# Altium schematic sheet TinyBeacon-144-RevD.SchDoc (continued)

## Library data of the parts
C1 C6 C7 C14 C16 C38 C41 C44 C47 C60 C62-C64 C66 C69-C71 = CMP-lc0pxm0az9mhmih9v1rd-1: Generic ceramic capacitor; Manufacturer Name: Murata; Manufacturer PN: GRM188R71H104KA93D; Dielectric: X7R; Lead-Free Status: Lead Free; Polarity: Non-Polarized; Rated Current: NC; Rated Voltage: 50V; Tolerance: ±10%; Category: Capacitor; Component Kind: Standard; Library Name: CommunityVault; Octopart Url: https://octopart.com/grm188r71h104ka93d-murata-196234; Package / Case: 0603 (1608 Metric); RoHS: Compliant; Supplier Name: Digikey; Supplier PN: 490-1519-1-ND; Supplier URL: http://www.digikey.ca/product-detail/en/GRM188R71H104KA93D/490-1519-1-ND/587854; Technology: Ceramic; VALUE: 100nF
C2 C10 = CMP-lc0pxm0az9mhmih9v1rd-1: Generic ceramic capacitor; Manufacturer Name: Murata; Manufacturer PN: GRM188R71E474KA12D; Dielectric: X7R; Lead-Free Status: Lead Free; Polarity: Non-Polarized; Rated Current: NC; Tolerance: ±10%; Category: Capacitor; Component Kind: Standard; Library Name: CommunityVault; Octopart Url: https://octopart.com/grm188r71e474ka12d-murata-196910; Package / Case: 0603 (1608 Metric); RoHS: Compliant; Supplier Name: Digikey; Supplier PN: 490-3291-1-ND; Supplier URL: http://www.digikey.ca/product-detail/en/GRM188R71E474KA12D/490-3291-1-ND/702832; Technology: Ceramic; VALUE: 470nF
C3 C11 = CMP-lc0pxm0az9mhmih9v1rd-1: Generic ceramic capacitor; Manufacturer Name: Murata; Manufacturer PN: GRM21BR71E475KA73L; Dielectric: X7R; Lead-Free Status: Lead Free; Polarity: Non-Polarized; Rated Current: NC; Tolerance: ±10%; Category: Capacitor; Component Kind: Standard; Library Name: CommunityVault; Octopart Url: https://octopart.com/grm21br71e475ka73l-murata-46693552; Package / Case: 0805 (2012 Metric); RoHS: Compliant; Supplier Name: Digikey; Supplier PN: 490-9962-1-ND; Supplier URL: http://www.digikey.ca/product-detail/en/GRM21BR71E475KA73L/490-9962-1-ND/5026449; Technology: Ceramic; VALUE: 4.7uF
C4 C15 = CMP-lc0pxm0az9mhmih9v1rd-1: Generic ceramic capacitor; Manufacturer Name: Murata; Manufacturer PN: GRM1885C1H471JA01D; Dielectric: C0G, NP0; Lead-Free Status: Lead Free; Polarity: Non-Polarized; Rated Current: NC; Tolerance: ±5%; Category: Capacitor; Component Kind: Standard; Library Name: CommunityVault; Octopart Url: https://octopart.com/grm1885c1h471ja01d-murata-196161; Package / Case: 0603 (1608 Metric); RoHS: Compliant; Supplier Name: Digikey; Supplier PN: 490-1443-1-ND; Supplier URL: http://www.digikey.ca/product-detail/en/GRM1885C1H471JA01D/490-1443-1-ND/587675; Technology: Ceramic; VALUE: 470pF
C5 C37 C39 = CMP-222772-3: Generic polarized capacitor; Manufacturer Name: AVX Corporation; Manufacturer PN: TPSA476K006R0800; Dielectric: Tantalum; Lead-Free Status: Lead Free; Rated Current: NC; Tolerance: ±10%; Category: Capacitor; Component Kind: Standard; Library Name: CommunityVault; Octopart Url: https://octopart.com/tpsa476k006r0800-avx-220336; Package / Case: 1206 (3216 Metric); Polarity: Polarized; RoHS: Compliant; Supplier Name: Digikey; Supplier PN: 478-3079-1-ND; Supplier URL: http://www.digikey.ca/product-detail/en/TPSA476K006R0800/478-3079-1-ND/809592; VALUE: 47uF
  C5 C37: Datasheet PDF: http://www.avx.com/docs/Catalogs/tps.pdf
C8 C17 C42 C45 C48 C72 = CMP-lc0pxm0az9mhmih9v1rd-1: Generic ceramic capacitor; Manufacturer Name: Murata; Manufacturer PN: GRM188R71H103KA01D; Dielectric: X7R; Lead-Free Status: Lead Free; Polarity: Non-Polarized; Rated Current: NC; Rated Voltage: 50V; Tolerance: ±10%; Category: Capacitor; Component Kind: Standard; Library Name: CommunityVault; Octopart Url: https://octopart.com/grm188r71h103ka01d-murata-81373; Package / Case: 0603 (1608 Metric); RoHS: Compliant; Supplier Name: Digikey; Supplier PN: 490-1512-1-ND; Supplier URL: http://www.digikey.ca/product-detail/en/GRM188R71H103KA01D/490-1512-1-ND/587862; Technology: Ceramic; VALUE: 10nF
C9 C18 C43 C46 C49-C51 C61 C65 C67 C73 = CMP-lc0pxm0az9mhmih9v1rd-1: Generic ceramic capacitor; Manufacturer Name: Murata; Manufacturer PN: GRM188R71H102KA01D; Dielectric: X7R; Lead-Free Status: Lead Free; Polarity: Non-Polarized; Rated Current: NC; Rated Voltage: 50V; Tolerance: ±10%; Category: Capacitor; Component Kind: Standard; Library Name: CommunityVault; Octopart Url: https://octopart.com/grm188r71h102ka01d-murata-62170; Package / Case: 0603 (1608 Metric); RoHS: Compliant; Supplier Name: Digikey; Supplier PN: 490-1494-1-ND; Supplier URL: http://www.digikey.ca/product-detail/en/GRM188R71H102KA01D/490-1494-1-ND/587851; Technology: Ceramic; VALUE: 1nF
C12 = CMP-222772-3: Generic polarized capacitor; Manufacturer Name: Kemet; Manufacturer PN: T494A106M020AT; Dielectric: Tantalum; Lead-Free Status: Lead Free; Rated Current: NC; Tolerance: ±20%; Category: Capacitor; Component Kind: Standard; Datasheet PDF: http://www.kemet.com/Lists/ProductCatalog/Attachments/256/KEM_T2008_T494.pdf; Library Name: CommunityVault; Octopart Url: https://octopart.com/t494a106m020at-kemet-11935444; Package / Case: 1206 (3216 Metric); Polarity: Polarized; RoHS: Compliant; Supplier Name: Digikey; Supplier PN: 399-5157-1-ND; Supplier URL: http://www.digikey.ca/product-detail/en/kemet/T494A106M020AT/399-5157-1-ND/1739493; VALUE: 10uF
C13 C74 = CMP-lc0pxm0az9mhmih9v1rd-2: Generic ceramic capacitor; Manufacturer Name: Murata; Manufacturer PN: GRM31CR61A476ME15L; Dielectric: X5R; Lead-Free Status: Lead Free; Polarity: Non-Polarized; Rated Current: NC; Rated Voltage: 10V; Tolerance: ±20%; Category: Capacitor; Component Kind: Standard; Library Name: CommunityVault; Octopart Url: https://octopart.com/grm31cr61a476me15l-murata-12963485; Package / Case: 1206 (3216 Metric); RoHS: Compliant; Supplier Name: Digikey; Supplier PN: 490-5528-1-ND; Supplier URL: http://www.digikey.ca/product-detail/en/GRM31CR61A476ME15L/490-5528-1-ND/2334924; Technology: Ceramic; VALUE: 47uF
C19 C57 = CMP-lc0pxm0az9mhmih9v1rd-1: Generic ceramic capacitor; Manufacturer Name: Murata; Manufacturer PN: GRM1885C1H470JA01D; Dielectric: C0G, NP0; Lead-Free Status: Lead Free; Polarity: Non-Polarized; Rated Current: NC; Rated Voltage: 50V; Tolerance: ±5%; Category: Capacitor; Component Kind: Standard; Library Name: CommunityVault; Octopart Url: https://octopart.com/grm1885c1h470ja01d-murata-196137; Package / Case: 0603 (1608 Metric); RoHS: Compliant; Supplier Name: Digikey; Supplier PN: 490-1419-1-ND; Supplier URL: http://www.digikey.ca/product-detail/en/murata-electronics-north-america/GRM1885C1H470JA01D/490-1419-1-ND/587616; Technology: Ceramic; VALUE: 47pF
C20 C23 = CMP-lc0pxm0az9mhmih9v1rd-1: Generic ceramic capacitor; Manufacturer Name: Murata; Manufacturer PN: GRM1885C1H152JA01D; Dielectric: C0G, NP0; Lead-Free Status: Lead Free; Polarity: Non-Polarized; Rated Current: NC; Rated Voltage: 50V; Tolerance: ±5%; Category: Capacitor; Component Kind: Standard; Library Name: CommunityVault; Octopart Url: https://octopart.com/grm1885c1h152ja01d-murata-196173; Package / Case: 0603 (1608 Metric); RoHS: Compliant; Supplier Name: Digikey; Supplier PN: 490-1455-1-ND; Supplier URL: http://www.digikey.ca/product-detail/en/GRM1885C1H152JA01D/490-1455-1-ND/587659; Technology: Ceramic; VALUE: 1500pF
C21 C22 C28 C30 = CMP-lc0pxm0az9mhmih9v1rd-1: Generic ceramic capacitor; Manufacturer Name: Murata; Manufacturer PN: GRM1885C1H101JA01D; Dielectric: C0G, NP0; Lead-Free Status: Lead Free; Polarity: Non-Polarized; Rated Current: NC; Rated Voltage: 50V; Tolerance: ±5%; Category: Capacitor; Component Kind: Standard; Library Name: CommunityVault; Octopart Url: https://octopart.com/grm1885c1h101ja01d-murata-196145; Package / Case: 0603 (1608 Metric); RoHS: Compliant; Supplier Name: Digikey; Supplier PN: 490-1427-1-ND; Supplier URL: http://www.digikey.ca/product-detail/en/murata-electronics-north-america/GRM1885C1H101JA01D/490-1427-1-ND/587633; Technology: Ceramic; VALUE: 100pF
C26 = CMP-lc0pxm0az9mhmih9v1rd-1: Generic ceramic capacitor; Manufacturer Name: Murata; Manufacturer PN: GRM188R71C105KA12D; Dielectric: X7R; Lead-Free Status: Lead Free; Polarity: Non-Polarized; Rated Current: NC; Rated Voltage: 16V; Tolerance: ±10%; Category: Capacitor; Component Kind: Standard; Library Name: CommunityVault; Octopart Url: https://octopart.com/grm188r71c105ka12d-murata-197452; Package / Case: 0603 (1608 Metric); RoHS: Compliant; Supplier Name: Digikey; Supplier PN: 490-3900-1-ND; Supplier URL: https://www.digikey.ca/product-detail/en/murata-electronics-north-america/GRM188R71C105KA12D/490-3900-1-ND/965942; Technology: Ceramic; VALUE: 1uF
C27 C29 C35 C53 C58 C59 = CMP-lc0pxm0az9mhmih9v1rd-1: Generic ceramic capacitor; Dielectric: NA; Lead-Free Status: Lead Free; Category: Capacitor; Component Kind: Standard; Library Name: CommunityVault; Manufacturer Name: NA; Manufacturer PN: NA; Octopart Url: NA; Package / Case: 0603 (1608 Metric); Polarity: NA; Rated Current: NA; Rated Voltage: NA; RoHS: Compliant; Supplier Name: NA; Supplier PN: NA; Supplier URL: NA; Technology: NA; Tolerance: NA; VALUE: NC
C34 = CMP-lc0pxm0az9mhmih9v1rd-1: Generic ceramic capacitor; Manufacturer Name: Murata; Manufacturer PN: GRM21BR60G107ME15L; Dielectric: X5R; Lead-Free Status: Lead Free; Polarity: Non-Polarized; Rated Voltage: 4V; Tolerance: ±20%; Category: Capacitor; Component Kind: Standard; Library Name: CommunityVault; Octopart Url: https://octopart.com/grm21br60g107me15l-murata-47096099; Package / Case: 0805 (2012 Metric); RoHS: Compliant; Supplier Name: Digikey; Supplier PN: 490-10510-1-ND; Supplier URL: https://www.digikey.ca/product-detail/en/murata-electronics-north-america/GRM21BR60G107ME15L/490-10510-1-ND/5026439; Technology: Ceramic; VALUE: 100uF
C40 = CMP-222772-3: Generic polarized capacitor; Manufacturer Name: AVX; Manufacturer PN: TLJA476M010R0600; Dielectric: Tantalum; Lead-Free Status: Lead Free; Rated Current: NC; Tolerance: ±20%; Category: Capacitor; Component Kind: Standard; Datasheet PDF: http://www.kemet.com/Lists/ProductCatalog/Attachments/254/KEM_T2005_T491.pdf; Library Name: CommunityVault; Octopart Url: https://octopart.com/tlja476m010r0600-avx-8398101; Package / Case: 1206 (3216 Metric); Polarity: Polarized; RoHS: Compliant; Supplier Name: Digikey; Supplier PN: 478-9009-1-ND; Supplier URL: http://www.digikey.ca/product-detail/en/avx-corporation/TLJA476M010R0600/478-9009-1-ND/4561875; VALUE: 47uF
C52 = CMP-lc0pxm0az9mhmih9v1rd-1: Generic ceramic capacitor; Manufacturer Name: Murata; Manufacturer PN: GRM1885C2A102JA01J; Dielectric: C0G, NP0; Lead-Free Status: Lead Free; Polarity: Non-Polarized; Rated Current: NC; Tolerance: ±5%; Category: Capacitor; Component Kind: Standard; Library Name: CommunityVault; Octopart Url: https://octopart.com/grm1885c2a102ja01j-murata-17287824; Package / Case: 0603 (1608 Metric); RoHS: Compliant; Supplier Name: Digikey; Supplier PN: 490-8275-1-ND; Supplier URL: http://www.digikey.ca/product-detail/en/murata-electronics-north-america/GRM1885C2A102JA01J/490-8275-1-ND/4380569; Technology: Ceramic; VALUE: 1nF
C54 = CMP-lc0pxm0az9mhmih9v1rd-1: Generic ceramic capacitor; Manufacturer Name: Murata; Manufacturer PN: GRM1885C2A620JA01D; Dielectric: C0G, NP0; Lead-Free Status: Lead Free; Polarity: Non-Polarized; Rated Current: NC; Tolerance: ±5%; Category: Capacitor; Component Kind: Standard; Library Name: CommunityVault; Octopart Url: https://octopart.com/grm1885c2a620ja01d-murata-196064; Package / Case: 0603 (1608 Metric); RoHS: Compliant; Supplier Name: Digikey; Supplier PN: 490-1346-1-ND; Supplier URL: http://www.digikey.ca/product-detail/en/murata-electronics-north-america/GRM1885C2A620JA01D/490-1346-1-ND/587687; Technology: Ceramic; VALUE: 62pF
C55 = CMP-lc0pxm0az9mhmih9v1rd-1: Generic ceramic capacitor; Manufacturer Name: Murata; Manufacturer PN: GRM1885C2A470JA01D; Dielectric: C0G, NP0; Lead-Free Status: Lead Free; Polarity: Non-Polarized; Rated Current: NC; Rated Voltage: 100V; Tolerance: ±5%; Category: Capacitor; Component Kind: Standard; Library Name: CommunityVault; Octopart Url: https://octopart.com/search?q=GRM1885C2A470JA01D; Package / Case: 0603 (1608 Metric); RoHS: Compliant; Supplier Name: Digikey; Supplier PN: 490-1343-1-ND; Supplier URL: http://www.digikey.ca/product-detail/en/murata-electronics-north-america/GRM1885C2A470JA01D/490-1343-1-ND/587692; Technology: Ceramic; VALUE: 47pF
C56 = CMP-lc0pxm0az9mhmih9v1rd-1: Generic ceramic capacitor; Manufacturer Name: Murata; Manufacturer PN: GRM1885C2A150FA01D; Dielectric: C0G, NP0; Lead-Free Status: Lead Free; Polarity: Non-Polarized; Rated Current: NC; Rated Voltage: 100V; Tolerance: ±1%; Category: Capacitor; Component Kind: Standard; Library Name: CommunityVault; Octopart Url: https://octopart.com/grm1885c2a150fa01d-murata-7895298; Package / Case: 0603 (1608 Metric); RoHS: Compliant; Supplier Name: Digikey; Supplier PN: 490-13359-1-ND; Supplier URL: http://www.digikey.ca/product-detail/en/murata-electronics-north-america/GRM1885C2A150FA01D/490-13359-1-ND/5973368; Technology: Ceramic; VALUE: 15pF
C75 C78 = CMP-lc0pxm0az9mhmih9v1rd-1: Generic ceramic capacitor; Manufacturer Name: Murata; Manufacturer PN: GRM1885C2A390JA01D; Dielectric: C0G, NP0; Lead-Free Status: Lead Free; Polarity: Non-Polarized; Rated Current: NC; Tolerance: ±5%; Category: Capacitor; Component Kind: Standard; Library Name: CommunityVault; Octopart Url: https://octopart.com/grm1885c2a390ja01d-murata-196059; Package / Case: 0603 (1608 Metric); RoHS: Compliant; Supplier Name: Digikey; Supplier PN: 490-1341-1-ND; Supplier URL: http://www.digikey.ca/product-detail/en/murata-electronics-north-america/GRM1885C2A390JA01D/490-1341-1-ND/587699; Technology: Ceramic; VALUE: 39pF
C76 C77 = CMP-lc0pxm0az9mhmih9v1rd-1: Generic ceramic capacitor; Manufacturer Name: Murata; Manufacturer PN: GRM1885C2A270JA01D; Dielectric: C0G, NP0; Lead-Free Status: Lead Free; Polarity: Non-Polarized; Rated Current: NC; Tolerance: ±5%; Category: Capacitor; Component Kind: Standard; Library Name: CommunityVault; Octopart Url: https://octopart.com/grm1885c2a270ja01d-murata-196055; Package / Case: 0603 (1608 Metric); RoHS: Compliant; Supplier Name: Digikey; Supplier PN: 490-1337-1-ND; Supplier URL: http://www.digikey.ca/product-detail/en/murata-electronics-north-america/GRM1885C2A270JA01D/490-1337-1-ND/587712; Technology: Ceramic; VALUE: 27pF
CV1 CV2 = CMP-9khhv8lmuc68m8vugv60-1: Generic variable capacitor; Manufacturer Name: Murata; Manufacturer PN: TZC3P200A110B00; Lead-Free Status: Lead Free; Polarity: Non-Polarized; Rated Current: NC; Serie: TZC3; Tolerance: NA; Category: Variable capacitor; Component Kind: Standard; Library Name: CommunityVault; Octopart Url: https://octopart.com/tzc3p200a110b00-murata-889206; Package / Case: Rectangular 4.50mm x 3.20mm; RoHS: Compliant; Supplier Name: Digikey; Supplier PN: 490-7154-ND; Supplier URL: http://www.digikey.ca/product-detail/en/murata-electronics-north-america/TZC3P200A110B00/490-7154-ND/2605008; VALUE: 5~20pF
D1-D3 = CMP-saaxis6nhgugjk9pybdm-4: Schottky Diode; Manufacturer Name: Diodes Incorporated; Manufacturer PN: B220A-13-F; Lead-Free Status: Lead Free; Rated Current: 2A; Rated Voltage: 20V; Voltage - Forward (Vf): 500mV @ 2A; Category: Schottky Diode; Component Kind: Standard; CustomComponentID: saaxis6nhgugjk9pybdm; Datasheet PDF: http://www.diodes.com/_files/datasheets/ds13004.pdf; Library Name: CommunityVault; Octopart Url: https://octopart.com/b220a-13-f-diodes+inc.-325773; Package / Case: SMA (DO-214AC); RoHS: Compliant; Supplier Name: Digikey; Supplier PN: B220A-FDICT-ND; Supplier URL: http://www.digikey.ca/product-detail/en/B220A-13-F/B220A-FDICT-ND/751528
D4 = CMP-284605-3: Generic TVS diode; Manufacturer Name: Murata; Lead-Free Status: Lead Free; Rated Voltage: 15V; Category: TVS Diode; Component Kind: Standard; Library Name: CommunityVault; Mounting Technology: LXES15AAA1-117; Octopart Url: https://octopart.com/lxes15aaa1-117-murata-30862315; Package / Case: 0402 (1005 Metric); RoHS: Compliant; Supplier Name: Digikey; Supplier PN: 490-9330-1-ND; Supplier URL: http://www.digikey.ca/product-detail/en/LXES15AAA1-117/490-9330-1-ND/4834048
D5 D8 = CMP-1544858-6: Green LED; Manufacturer Name: Lite-On; Manufacturer PN: LTST-C171KGKT; Brightness: 35mcd; Lead-Free Status: Lead Free; Rated Current: 20mA; Wavelength: 571nm; Category: LED; Color: Green; Component Kind: Standard; Datasheet PDF: http://optoelectronics.liteon.com/upload/download/DS22-2000-118/S_110_LTST-C171KGKT.pdf; Library Name: CommunityVault; Octopart URL: https://octopart.com/ltst-c171kgkt-lite-on-549888; Package / Case: 0805 (2012 Metric); RoHS: Compliant; Supplier Name: Digikey; Supplier PN: 160-1426-1-ND; Supplier URL: http://www.digikey.ca/product-detail/en/lite-on-inc/LTST-C171KGKT/160-1426-1-ND/386798
D6 = CMP-1544858-7: Red LED; Manufacturer Name: Lite-On; Manufacturer PN: LTST-C171KRKT; Brightness: 54 mcd; Lead-Free Status: Lead Free; Rated Current: 20mA; Wavelength: 631nm; Category: LED; Color: Red; Component Kind: Standard; Datasheet PDF: http://optoelectronics.liteon.com/upload/download/DS22-2000-109/S_110_LTST-C171KRKT.pdf; Library Name: CommunityVault; Octopart URL: https://octopart.com/ltst-c171krkt-lite-on-549889; Package / Case: 0805 (2012 Metric); RoHS: Compliant; Supplier Name: Digikey; Supplier PN: 160-1427-1-ND; Supplier URL: http://www.digikey.ca/product-detail/en/LTST-C171KRKT/160-1427-1-ND/386800
D7 = CMP-1544858-8: Yellow LED; Manufacturer Name: Lite-On; Manufacturer PN: LTST-C171KSKT; Brightness: 50mcd; Color: Red; Lead-Free Status: Lead Free; Rated Current: 20mA; Wavelength: 587nm; Category: LED; Component Kind: Standard; Datasheet PDF: http://optoelectronics.liteon.com/upload/download/DS22-2000-110/S_110_LTST-C171KSKT.pdf; Library Name: CommunityVault; Octopart URL: https://octopart.com/ltst-c171kskt-lite-on-549859; Package / Case: 0805 (2012 Metric); RoHS: Compliant; Supplier Name: Digikey; Supplier PN: 160-1428-1-ND; Supplier URL: http://www.digikey.ca/product-detail/en/lite-on-inc/LTST-C171KSKT/160-1428-1-ND/386802
F1-F5 = CMP-gkzqqvpzrlrunm2b6w61-3: Fixation Round 3mm; Lead-Free Status: Lead Free; Component Kind: Standard; CustomComponentID: gkzqqvpzrlrunm2b6w61; Library Name: CommunityVault; RoHS: Compliant
  F5: Category: PCB
FB1-FB6 = CMP-vk70ppgduhlrisxj3t6o-3: Generic ferrite bead; Manufacturer Name: Murata; Manufacturer PN: BLM21AG471SN1D; DC Resistance (DCR) (Max): 190 mOhm; Impedance @ Frequency: 470 Ohm @ 100MHz; Lead-Free Status: Lead Free; Rated Current: 700mA; Rated Voltage: NC; Category: Filter; Component Kind: Standard; Library Name: CommunityVault; Octopart Url: https://octopart.com/blm21ag471sn1d-murata-368342; Package / Case: 0805 (2012 Metric); RoHS: Compliant; Supplier Name: Digikey; Supplier PN: 490-1039-1-ND; Supplier URL: http://www.digikey.ca/product-detail/en/murata-electronics-north-america/BLM21AG471SN1D/490-1039-1-ND/584487; VALUE: 470R
J1 = CMP-4734335-6: Power Jack 2.1mm connector; Lead-Free Status: Lead Free; Category: Connector; Component Kind: Standard; Datasheet PDF: http://www.cui.com/product/resource/pj-002ah-smt.pdf; Library Name: CommunityVault; Manufacturer Name: CUI; Manufacturer PN: PJ-002AH-SMT-TR; OctopartID: 294ae1cbe021f68d; Octopart Url: https://octopart.com/pj-002ah-smt-tr-cui-25947149; Package / Case: Custom; RoHS: Compliant; Supplier Name: Digikey; Supplier PN: CP-002AHPJCT-ND; Supplier URL: http://www.digikey.ca/product-detail/en/PJ-002AH-SMT-TR/CP-002AHPJCT-ND/669692
J2 J3 = CMP-bd3b743192d962fc-7: SMA Jack; Manufacturer Name: Cinch Connectivity Solutions Johnson; Manufacturer PN: 142-0701-801; Lead-Free Status: Lead Free; Category: Connector; Component Kind: Standard; Datasheet PDF: https://cinchconnectivity.com/OA_MEDIA/drawings/dr-1420701801.pdf; Library Name: CommunityVault; OctopartID: bd3b743192d962fc; Octopart URL: http://octopart.com/142-0701-801-emerson+network+power+-+johnson-2692; Package / Case: SMA; RoHS: Compliant; Size-Height: 7.92 mm; Size-Length: 11.2 mm; Supplier Name: Digikey; Supplier PN: J502-ND; Supplier URL: http://www.digikey.com/product-detail/en/142-0701-801/J502-ND/35280
L1 = CMP-vwua5nnhs1xk3xc2ttx4-1: Inductor Shielded; Manufacturer Name: Murata Electronics; Manufacturer PN: LQH55DN6R8M03L; DC Resistance (DCR): 103.6 mOhm Max; Frequency - Self Resonant: 25MHz; Lead-Free Status: Lead Free; Q: NC; Tolerance: ±20%; Category: Inductor; Component Kind: Standard; Library Name: CommunityVault; Octopart Url: https://octopart.com/lqh55dn6r8m03l-murata-892319; Package / Case: 2220 (5650 Metric); Rated Voltage: NC; RoHS: Compliant; Supplier Name: Digikey; Supplier PN: 490-11697-1-ND; Supplier URL: http://www.digikey.ca/product-detail/en/LQH55DN6R8M03L/490-11697-1-ND/5333537; Technology: Ferrite; VALUE: 6.8uH
L2 = CMP-vwua5nnhs1xk3xc2ttx4-1: Inductor Shielded; Manufacturer Name: Murata Electronics; Manufacturer PN: LQH55DN4R7M03L; DC Resistance (DCR): 57.4 mOhm Max; Frequency - Self Resonant: 30 MHz; Lead-Free Status: Lead Free; Q: NC; Tolerance: ±20%; Category: Inductor; Component Kind: Standard; Library Name: CommunityVault; Octopart Url: https://octopart.com/lqh55dn4r7m03l-murata-890441; Package / Case: 2220 (5650 Metric); Rated Voltage: NC; RoHS: Compliant; Supplier Name: Digikey; Supplier PN: 490-9760-1-ND; Supplier URL: http://www.digikey.ca/product-detail/en/LQH55DN4R7M03L/490-9760-1-ND/4934959; Technology: Ferrite; VALUE: 4.7uH
L3 L8 = CMP-ikx6rag9xh6lf8sgm74d-2: Generic inductor; Manufacturer Name: Abracon LLC; Manufacturer PN: AISC-0805-R027G-T; DC Resistance (DCR): 170 mOhm Max; Lead-Free Status: Lead Free; Q: 50 @ 500MHz; Rated Current: 600mA; Rated Voltage: NC; Tolerance: ±2%; Category: Inductor; Component Kind: Standard; Datasheet PDF: http://www.abracon.com/Magnetics/new/AISC-0805(F).pdf; Library Name: CommunityVault; Octopart Url: https://octopart.com/aisc-0805-r027g-t-abracon-22130219; Package / Case: 0805; RoHS: Compliant; Supplier Name: Digikey; Supplier PN: AISC-0805-R027G-TCT-ND; Supplier URL: http://www.digikey.ca/product-detail/en/abracon-llc/AISC-0805-R027G-T/AISC-0805-R027G-TCT-ND/3060486; Technology: Air; VALUE: 27nH
L6 = CMP-ikx6rag9xh6lf8sgm74d-3: Generic inductor; Manufacturer Name: Abracon LLC; Manufacturer PN: AIAC-4125C-R380J-T; DC Resistance (DCR): 25.5 mOhm Max; Lead-Free Status: Lead Free; Q: 100 @ 50MHz; Rated Current: 2.5A; Rated Voltage: NC; Tolerance: ±5%; Category: Inductor; Component Kind: Standard; Datasheet PDF: http://www.abracon.com/Magnetics/chips/AIAC-4125C.pdf; Library Name: CommunityVault; Octopart Url: https://octopart.com/aiac-4125c-r380j-t-abracon-24987475; Package / Case: Nonstandard  (9.00mm x 4.40mm); RoHS: Compliant; Supplier Name: Digikey; Supplier PN: 535-12013-1-ND; Supplier URL: http://www.digikey.ca/product-detail/en/AIAC-4125C-R380J-T/535-12013-1-ND/3737488; Technology: Air; VALUE: 380nH
L7 = CMP-ikx6rag9xh6lf8sgm74d-2: Generic inductor; Manufacturer Name: Abracon LLC; Manufacturer PN: AISC-1206-R39J-T; DC Resistance (DCR): 800 mOhm Max; Lead-Free Status: Lead Free; Q: 45 @ 150MHz; Rated Current: 530mA; Rated Voltage: NC; Tolerance: ±5%; Category: Inductor; Component Kind: Standard; Datasheet PDF: http://www.abracon.com/Magnetics/new/AISC-1206.pdf; Library Name: CommunityVault; Octopart Url: https://octopart.com/aisc-1206-r39j-t-abracon-33964677; Package / Case: 1206; RoHS: Compliant; Supplier Name: Digikey; Supplier PN: 535-12422-1-ND; Supplier URL: http://www.digikey.ca/product-detail/en/AISC-1206-R39J-T/535-12422-1-ND/4571304; Technology: Air; VALUE: 390nH
L9 = CMP-ikx6rag9xh6lf8sgm74d-3: Generic inductor; Manufacturer Name: Abracon LLC; Manufacturer PN: AIAC-1812-22NK-T; DC Resistance (DCR): 4.8 mOhm Max; Lead-Free Status: Lead Free; Q: 100 @ 150MHz; Rated Current: 3.2A; Rated Voltage: NC; Tolerance: ±5%; Category: Inductor; Component Kind: Standard; Datasheet PDF: http://www.abracon.com/Magnetics/chips/AIAC-1812.pdf; Library Name: CommunityVault; Octopart Url: https://octopart.com/aiac-1812-22nk-t-abracon-24987452; Package / Case: Nonstandard (4.70mm x 3.50); RoHS: Compliant; Supplier Name: Digikey; Supplier PN: 535-11990-1-ND; Supplier URL: http://www.digikey.ca/product-detail/en/abracon-llc/AIAC-1812-22NK-T/535-11990-1-ND/3737500; Technology: Air; VALUE: 22nH
L10 L11 = CMP-ikx6rag9xh6lf8sgm74d-3: Generic inductor; Manufacturer Name: Abracon LLC; Manufacturer PN: AIAC-1812-47NJ-T; DC Resistance (DCR): 6.9 mOhm Max; Lead-Free Status: Lead Free; Q: 100 @ 150MHz; Rated Current: 2.8A; Rated Voltage: NC; Tolerance: ±5%; Category: Inductor; Component Kind: Standard; Datasheet PDF: http://www.abracon.com/Magnetics/chips/AIAC-1812.pdf; Library Name: CommunityVault; Octopart Url: https://octopart.com/aiac-1812-47nj-t-abracon-24987456; Package / Case: Nonstandard (4.70mm x 3.50); RoHS: Compliant; Supplier Name: Digikey; Supplier PN: 535-11994-1-ND; Supplier URL: http://www.digikey.ca/product-detail/en/abracon-llc/AIAC-1812-47NJ-T/535-11994-1-ND/3737471; Technology: Air; VALUE: 47nH
P1 = CMP-ksul0xljhzr34sdh77co-2: Header 5x2, standard 2.54mm spacing; Component Kind: Standard; CustomComponentID: ksul0xljhzr34sdh77co; Library Name: CommunityVault
P2 P3 = CMP-jyrupiaq35ocwd822w6n-3: Header 3x1, standard 2.54mm spacing; Component Kind: Standard; CustomComponentID: jyrupiaq35ocwd822w6n; Library Name: CommunityVault
R1 = CMP-1855144-5: RES SMD; Manufacturer Name: Rohm Semiconductor; Manufacturer PN: MCR03ERTF3093; Lead-Free Status: Lead Free; Rated Power: 0.1W, 1/10W; Tolerance: ±1%; Category: Resistor; Component Kind: Standard; Library Name: CommunityVault; Octopart Url: https://octopart.com/mcr03ertf3093-rohm-21223297; Package / Case: 0603 (1608 Metric); RoHS: Compliant; Series: MCR03; Supplier Name: Digikey; Supplier PN: RHM309KCFCT-ND; Supplier URL: http://www.digikey.ca/product-detail/en/MCR03ERTF3093/RHM309KCFCT-ND/4084128; VALUE: 309k
R2 R7 = CMP-1855144-5: RES SMD; Manufacturer Name: Rohm Semiconductor; Manufacturer PN: MCR03ERTF1802; Lead-Free Status: Lead Free; Rated Power: 0.1W, 1/10W; Tolerance: ±5%; Category: Resistor; Component Kind: Standard; Library Name: CommunityVault; Octopart Url: https://octopart.com/mcr03ertf1802-rohm-21223161; Package / Case: 0603 (1608 Metric); RoHS: Compliant; Series: MCR03; Supplier Name: Digikey; Supplier PN: RHM18KCFCT-ND; Supplier URL: http://www.digikey.ca/product-detail/en/MCR03ERTF1802/RHM18KCFCT-ND/4084018; VALUE: 18k
R3 R8 = CMP-1855144-5: RES SMD; Manufacturer Name: Rohm Semiconductor; Manufacturer PN: MCR03ERTF4022; Lead-Free Status: Lead Free; Rated Power: 0.1W, 1/10W; Tolerance: ±1%; Category: Resistor; Component Kind: Standard; Library Name: CommunityVault; Octopart Url: https://octopart.com/mcr03ertf4022-rohm-21223369; Package / Case: 0603 (1608 Metric); RoHS: Compliant; Series: MCR03; Supplier Name: Digikey; Supplier PN: RHM40.2KCFCT-ND; Supplier URL: http://www.digikey.ca/product-detail/en/MCR03ERTF4022/RHM40.2KCFCT-ND/4084189; VALUE: 40.2k
R4 = CMP-1855144-5: RES SMD; Manufacturer Name: Rohm Semiconductor; Manufacturer PN: MCR03ERTF3302; Lead-Free Status: Lead Free; Rated Power: 0.1W, 1/10W; Tolerance: ±1%; Category: Resistor; Component Kind: Standard; Library Name: CommunityVault; Octopart Url: https://octopart.com/mcr03ertf3302-rohm-21223313; Package / Case: 0603 (1608 Metric); RoHS: Compliant; Series: MCR03; Supplier Name: Digikey; Supplier PN: RHM33.0KCFCT-ND; Supplier URL: http://www.digikey.ca/product-detail/en/rohm-semiconductor/MCR03ERTF3302/RHM33.0KCFCT-ND/2796480; VALUE: 33k
R5 R9 R22 R23 R35 R37 R39 = CMP-1855144-5: RES SMD; Manufacturer Name: Rohm Semiconductor; Manufacturer PN: MCR03ERTF4702; Lead-Free Status: Lead Free; Rated Power: 0.1W, 1/10W; Tolerance: ±1%; Category: Resistor; Component Kind: Standard; Library Name: CommunityVault; Octopart Url: https://octopart.com/mcr03ertf4702-rohm-21223409; Package / Case: 0603 (1608 Metric); RoHS: Compliant; Series: MCR03; Supplier Name: Digikey; Supplier PN: RHM47.0KCFCT-ND; Supplier URL: http://www.digikey.ca/product-detail/en/MCR03ERTF4702/RHM47.0KCFCT-ND/2796484; VALUE: 47k
R6 = CMP-1855144-5: RES SMD; Manufacturer Name: Rohm Semiconductor; Manufacturer PN: MCR03ERTF1803; Lead-Free Status: Lead Free; Rated Power: 0.1W, 1/10W; Tolerance: ±1%; Category: Resistor; Component Kind: Standard; Library Name: CommunityVault; Octopart Url: https://octopart.com/mcr03ertf1803-rohm-21223162; Package / Case: 0603 (1608 Metric); RoHS: Compliant; Series: MCR03; Supplier Name: Digikey; Supplier PN: RHM180KCFCT-ND; Supplier URL: http://www.digikey.ca/product-detail/en/MCR03ERTF1803/RHM180KCFCT-ND/4084019; VALUE: 180k
R10 R21 = CMP-1855144-5: RES SMD; Manufacturer Name: Rohm Semiconductor; Manufacturer PN: ESR10EZPJ100; Lead-Free Status: Lead Free; Tolerance: ±5%; Category: Resistor; Component Kind: Standard; Library Name: CommunityVault; Octopart Url: https://octopart.com/esr10ezpj100-rohm-19170069; Package / Case: 0805 (2012 Metric); RoHS: Compliant; Series: ESR; Supplier Name: Digikey; Supplier PN: RHM10KCT-ND; Supplier URL: http://www.digikey.ca/product-detail/en/rohm-semiconductor/ESR10EZPJ100/RHM10KCT-ND/1762949; VALUE: 10
R11 R14 = CMP-1855144-5: RES SMD; Manufacturer Name: Rohm Semiconductor; Manufacturer PN: MCR03EZPJ000; Lead-Free Status: Lead Free; Rated Power: 0.1W, 1/10W; Tolerance: ±1%; Category: Resistor; Component Kind: Standard; Library Name: CommunityVault; Package / Case: 0603 (1608 Metric); RoHS: Compliant; Series: MCR; Supplier Name: Digikey; Supplier PN: RHM0.0GCT-ND; Supplier URL: http://www.digikey.ca/product-detail/en/rohm-semiconductor/MCR03EZPJ000/RHM0.0GCT-ND/628906; VALUE: 0
  R11: Octopart Url: https://octopart.com/mcr03ezpj000-rohm-1374831
R12 = CMP-1855144-5: RES SMD; Manufacturer Name: Rohm Semiconductor; Manufacturer PN: MCR03ERTF1003; Lead-Free Status: Lead Free; Rated Power: 0.1W, 1/10W; Tolerance: ±1%; Category: Resistor; Component Kind: Standard; Library Name: CommunityVault; Octopart Url: https://octopart.com/mcr03ertf1003-rohm-21223022; Package / Case: 0603 (1608 Metric); RoHS: Compliant; Series: MCR03; Supplier Name: Digikey; Supplier PN: RHM100KCFCT-ND; Supplier URL: http://www.digikey.ca/product-detail/en/rohm-semiconductor/MCR03ERTF1003/RHM100KCFCT-ND/2796461; VALUE: 100k
R13 = CMP-1855144-5: RES SMD; Manufacturer Name: Rohm Semiconductor; Manufacturer PN: MCR03ERTF1001; Lead-Free Status: Lead Free; Rated Power: 0.1W, 1/10W; Tolerance: ±1%; Category: Resistor; Component Kind: Standard; Library Name: CommunityVault; Package / Case: 0603 (1608 Metric); RoHS: Compliant; Series: MCR03; Supplier Name: Digikey; Supplier PN: RHM1.00KCFCT-ND; Supplier URL: http://www.digikey.ca/product-detail/en/MCR03ERTF1001/RHM1.00KCFCT-ND/2796459; VALUE: 1k
R15 R16 R19 R24 R27 R31-R33 = CMP-1855144-5: RES SMD; Manufacturer Name: Rohm Semiconductor; Manufacturer PN: MCR03ERTF1002; Lead-Free Status: Lead Free; Rated Power: 0.1W, 1/10W; Tolerance: ±1%; Category: Resistor; Component Kind: Standard; Library Name: CommunityVault; Octopart Url: https://octopart.com/mcr03ertf1002-rohm-21223021; Package / Case: 0603 (1608 Metric); RoHS: Compliant; Series: MCR03; Supplier Name: Digikey; Supplier PN: RHM10.0KCFCT-ND; Supplier URL: http://www.digikey.ca/product-detail/en/MCR03ERTF1002/RHM10.0KCFCT-ND/2796460; VALUE: 10k
R17 R30 R34 = CMP-1855144-5: RES SMD; Manufacturer Name: Rohm Semiconductor; Manufacturer PN: MCR03ERTF33R0; Lead-Free Status: Lead Free; Rated Power: 0.1W, 1/10W; Tolerance: ±1%; Category: Resistor; Component Kind: Standard; Library Name: CommunityVault; Octopart Url: https://octopart.com/mcr03ertf33r0-rohm-21223320; Package / Case: 0603 (1608 Metric); RoHS: Compliant; Series: MCR03; Supplier Name: Digikey; Supplier PN: RHM33.0CFCT-ND; Supplier URL: http://www.digikey.ca/product-detail/en/MCR03ERTF33R0/RHM33.0CFCT-ND/2796481; VALUE: 33
R18 R20 R25 R26 R40 = CMP-1855144-5: RES SMD; Manufacturer Name: NA; Lead-Free Status: Lead Free; Category: Resistor; Component Kind: Standard; Library Name: CommunityVault; Manufacturer PN: NA; Package / Case: 0603 (1608 Metric); Rated Power: NA; RoHS: Compliant; Series: NA; Supplier Name: NA; Supplier PN: NA; Supplier URL: NA; Tolerance: NA; VALUE: NC
R28 R36 R38 = CMP-1855144-5: RES SMD; Manufacturer Name: Rohm Semiconductor; Manufacturer PN: MCR03ERTF4701; Lead-Free Status: Lead Free; Rated Power: 0.1W, 1/10W; Tolerance: ±1%; Category: Resistor; Component Kind: Standard; Library Name: CommunityVault; Octopart Url: https://octopart.com/mcr03ertf4701-rohm-21223408; Package / Case: 0603 (1608 Metric); RoHS: Compliant; Series: MCR03; Supplier Name: Digikey; Supplier PN: RHM4.70KCFCT-ND; Supplier URL: http://www.digikey.ca/product-detail/en/MCR03ERTF4701/RHM4.70KCFCT-ND/2796483; VALUE: 4.7k
R29 = CMP-1855144-5: RES SMD; Manufacturer Name: Rohm Semiconductor; Manufacturer PN: ESR10EZPJ220; Lead-Free Status: Lead Free; Tolerance: ±5%; Category: Resistor; Component Kind: Standard; Library Name: CommunityVault; Package / Case: 0805 (2012 Metric); RoHS: Compliant; Series: ESR; Supplier Name: Digikey; Supplier PN: RHM22KCT-ND; Supplier URL: http://www.digikey.ca/product-detail/en/rohm-semiconductor/ESR10EZPJ220/RHM22KCT-ND/1762989; VALUE: 22
RT1 = CMP-5poqzt89fgb6dw7dt2ra-1: Generic thermistors; Manufacturer Name: Murata; Manufacturer PN: NCP18XV103J03RB; Lead-Free Status: Lead Free; Rated Power: 0.1W, 1/10W; Tolerance: ±5%; Category: Thermistors; Component Kind: Standard; Library Name: CommunityVault; Package / Case: 0603 (1608 Metric); RoHS: Compliant; Series: MCR; Supplier Name: Digikey; Supplier PN: 490-6944-1-ND; Supplier URL: http://www.digikey.ca/product-detail/en/murata-electronics-north-america/NCP18XV103J03RB/490-6944-1-ND/3846141; VALUE: ~10k
RV1 = CMP-gmvj5zndc3csziepcpud-3: Trim Pots, PVG5 Series; Manufacturer Name: Murata; Manufacturer PN: PVG5A103C03R00; Lead-Free Status: Lead Free; Number of Turns: 11; Rated Power: 0.25W, 1/4W; Category: Trimmer Potentiometers; Component Kind: Standard; Datasheet PDF: http://www.murata.com/~/media/webrenewal/support/library/catalog/products/resistor/trimmer/r50e.ashx; Library Name: CommunityVault; Octopart Url: https://octopart.com/pvg5a103c03r00-murata-12669551; Package / Case: Non-standard; RoHS: Compliant; Supplier Name: Digikey; Supplier PN: 490-2661-1-ND; Supplier URL: http://www.digikey.ca/product-detail/en/murata-electronics-north-america/PVG5A103C03R00/490-2661-1-ND/666237; VALUE: 0~10k
U1 U2 = CMP-1482847-6: DC-DC Step-Down Switching Regulator, 36V, 2A, 2.4MHz; Manufacturer Name: Linear Technology; Manufacturer PN: LT3480EDD#PBF; Input Voltage: 3.6V ~ 36 V; Lead-Free Status: Lead Free; Output Current: 2.0 A; Output Voltage: 20.0 V; Rated Voltage: Up to 20V; Category: IC / Regulator; Component Kind: Standard; Datasheet PDF: https://cds.linear.com/docs/en/datasheet/3480fe.pdf; Library Name: CommunityVault; OctopartID: 95545c4d2c304a93; Octopart Url: https://octopart.com/lt3480edd%23pbf-linear+technology-1285122; Package / Case: 10-VSON (3x3); Rated Current: 2A; RoHS: Compliant; Supplier Name: Digikey; Supplier PN: LT3480EDD#PBF-ND; Supplier URL: http://www.digikey.ca/product-detail/en/LT3480EDD%23PBF/LT3480EDD%23PBF-ND/1530931
U3 = CMP-1493287-3: LDO Regulator 500mA Fixed Output 3.3V; Manufacturer Name: Microchip Technology; Manufacturer PN: TC1262-3.3VDBTR; Lead-Free Status: Lead Free; Rated Voltage: Up to 6V; Voltage Dropout: 0.35V @ 500mA; Category: IC / LDO; Component Kind: Standard; Datasheet PDF: http://ww1.microchip.com/downloads/en/DeviceDoc/21373C.pdf; Library Name: CommunityVault; Octopart Url: https://octopart.com/tc1262-3.3vdbtr-microchip-540514; Package / Case: SOT-223; Quiescent Current: 3.3V; Rated Current: 500mA; RoHS: Compliant; Supplier Name: Digikey; Supplier PN: TC1262-3.3VDBCT-ND; Supplier URL: http://www.digikey.ca/product-detail/en/TC1262-3.3VDBTR/TC1262-3.3VDBCT-ND/669517; VALUE: 3.3V
U4 = CMP-6e0ca43b2058b1cb-5: SMD GPS Module; Lead-Free Status: Lead Free; Rated Current: 17mA; Rated Voltage: 1.65 - 3.6V; Category: Integrated module; Component Kind: Standard; Datasheet PDF: https://www.u-blox.com/sites/default/files/MAX-M8-FW3_DataSheet_%28UBX-15031506%29.pdf; Library Name: CommunityVault; Manufacturer Name: u-blox; Package / Case: Custom; RoHS: Compliant; Supplier Name: u-blox; Supplier PN: MAX-M8Q; Supplier URL: https://shop-america.u-blox.com/en/usd/3~100~AMERICAS/Position-Time/Standard-Precision-GNSS/MAX-series
U5 = CMP-uasb8tmghxms4rr4tccw-3: UHS Single Inverter; Manufacturer Name: Fairchild Semiconductor; Manufacturer PN: NC7SZ04M5X; Lead-Free Status: Lead Free; Category: IC; Component Kind: Standard; Datasheet PDF: https://www.fairchildsemi.com/datasheets/NC/NC7SZ04.pdf; Library Name: CommunityVault; Octopart Url: https://octopart.com/nc7sz04m5x-fairchild+semiconductor-467532; Package / Case: SOT-23-5; RoHS: Compliant; Supplier Name: Digikey; Supplier PN: NC7SZ04M5XCT-ND; Supplier URL: http://www.digikey.ca/product-detail/en/fairchild-semiconductor/NC7SZ04M5X/NC7SZ04M5XCT-ND/673388
U6 = CMP-zm9q8iv22gewj4d96nnx-2: Oscillator VCTCXO 10M; Manufacturer Name: Connor-Winfield; Manufacturer PN: T604-010.0M; Lead-Free Status: Lead Free; Rated Current: 2.1mA; Rated Voltage: 3.3; Tolerance: ±280ppb; Category: Oscillator (VCTCXO); Component Kind: Standard; CustomComponentID: zm9q8iv22gewj4d96nnx; Datasheet PDF: http://www.conwin.com/datasheets/tx/tx176.pdf; Library Name: CommunityVault; Octopart Url: https://octopart.com/t604-010.0m-connor-winfield-25316329; Package / Case: 10-QFN (Non Standard); RoHS: Compliant; Supplier Name: Digikey; Supplier PN: CW769CT-ND; Supplier URL: http://www.digikey.ca/product-detail/en/T604-010.0M/CW769CT-ND/3757226
U7 = CMP-3238540-6: Clock Generator VCXO, I2C-Programmable; Manufacturer Name: Silicon Labs; Manufacturer PN: SI5351C-B-GM; Lead-Free Status: Lead Free; Category: IC / PLL; Component Kind: Standard; Datasheet PDF: https://www.silabs.com/Support%20Documents/TechnicalDocs/Si5350-51-B-short.pdf; Library Name: CommunityVault; OctopartID: 2103e9287875fec4; Octopart Url: https://octopart.com/si5351c-b-gm-silicon+labs-24879781; Package / Case: 20-QFN (4x4); RoHS: Compliant; Supplier Name: Digikey; Supplier PN: 336-2402-ND; Supplier URL: http://www.digikey.ca/product-detail/en/silicon-labs/SI5351C-B-GM/336-2402-ND/3679850
U8 = CMP-97xr1clx4kkyemyoql6v-2: Two Input XOR GATE; Manufacturer Name: Fairchild Semiconductor; Manufacturer PN: NC7SZ86M5X; Lead-Free Status: Lead Free; Rated Current: 32mA; Rated Voltage: 1.65 V ~ 5.5 V; Category: IC; Component Kind: Standard; Datasheet PDF: http://www.fairchildsemi.com/datasheets/NC/NC7SZ86.pdf; Library Name: CommunityVault; Octopart Url: https://octopart.com/nc7sz86m5x-fairchild+semiconductor-467576; Package / Case: SOT-23-6; RoHS: Compliant; Supplier Name: Digikey; Supplier PN: NC7SZ86M5XCT-ND; Supplier URL: http://www.digikey.ca/product-detail/en/NC7SZ86M5X/NC7SZ86M5XCT-ND/673402
U10 = CMP-1171439-4: Load Switch 1.8 A; Manufacturer Name: Texas Instruments; Lead-Free Status: Lead Free; Rated Current: 1.8A; Rated Voltage: 1.4 V ~ 5.5 V; Category: IC; Component Kind: Standard; Datasheet PDF: http://www.ti.com/lit/ds/symlink/tps22929d.pdf; Library Name: CommunityVault; Manufacturer PN: TPS22929DDBVT; Octopart Url: https://octopart.com/tps22929ddbvt-texas+instruments-18517554; Package / Case: SOT-23; RoHS: Compliant; Supplier Name: Digikey; Supplier PN: 296-30174-1-ND; Supplier URL: http://www.digikey.ca/product-detail/en/TPS22929DDBVT/296-30174-1-ND/2812728
U11 = CMP-sch2sy2co3tqeu47zjwu-4: FET PHEMT 2GHZ 4.5V 200mA; Manufacturer Name: Avago; Frequency (f): 50MHz - 6GHz; Gain: 16 dB; Lead-Free Status: Lead Free; NF: 1.5 dB; Output Power: 27dBm; PAE: 55% @ P1dB; Rated Voltage: 5V; Category: RF; Component Kind: Standard; CustomComponentID: sch2sy2co3tqeu47zjwu; Datasheet PDF: https://media.digikey.com/pdf/Data%20Sheets/Avago%20PDFs/ATF-52189.pdf; Library Name: CommunityVault; Manufacturer PN: ATF-52189-BLK; Package / Case: SOT-89-3; RoHS: Compliant; Supplier Name: Digikey; Supplier PN: 516-2371-ND; Supplier URL: http://www.digikey.ca/product-detail/en/0/516-2371-ND
U12 = CMP-6qmx3eddx56h6kah3vs2-2: LDMOS FET; Manufacturer Name: CEL; Manufacturer PN: NE5550979A-A; Frequency (f): 100-900MHz; Gain: 22 dB; Lead-Free Status: Lead Free; Rated Power (P-1dB): 38.6dBm; Rated Voltage: 30V; Category: RF LDMOS FET; Component Kind: Standard; CustomComponentID: 6qmx3eddx56h6kah3vs2; Datasheet PDF: http://www.cel.com/pdf/datasheets/ne5550979a.pdf; Library Name: CommunityVault; Octopart Url: https://octopart.com/ne5550979a-a-cel-22392611; Package / Case: Non-standard; RoHS: Compliant; Supplier Name: Digikey; Supplier PN: NE5550979A-A-ND; Supplier URL: http://www.digikey.ca/product-detail/en/NE5550979A-A/NE5550979A-A-ND/3728646
U13 = CMP-973187-11: ATmega Series 20 MHz 32 KB Flash 2 KB SRAM 8-Bit Microcontroller - QFN-32; Clock Speed: 20 MHz; Lead-Free Status: Lead Free; Memory - EEPROM Size: 1K x 8; Memory - Flash Size: 32KB (16K x 16); Memory - RAM Size: 2K x 8; Rated Voltage: 1.8 V ~ 5.5 V; Category: IC; Component Kind: Standard; Datasheet PDF: http://www.atmel.com/images/Atmel-8271-8-bit-AVR-Microcontroller-ATmega48A-48PA-88A-88PA-168A-168PA-328-328P_datasheet_Complete.pdf; Library Name: CommunityVault; OctopartID: 2bc4e04af015f403; Octopart Url: http://octopart.com/atmega328p-mu-atmel-42270391; Package / Case: 32-VQFN (5x5); REACH SVHC Compliance: No SVHC; RoHS: Compliant; Supplier Name: Digikey; Supplier PN: ATMEGA328P-MU-ND; Supplier URL: http://www.digikey.ca/product-detail/en/ATMEGA328P-MU/ATMEGA328P-MU-ND/1914588
X1 = CMP-y4jydfej4kcq2cj8xjpo-3: Generic crystal / XO; Manufacturer Name: Abracon LLC; Manufacturer PN: ABM8-27.000MHZ-10-1-U-T; Lead-Free Status: Lead Free; Tolerance: ±10ppm; VALUE: 27MHz; Category: Crystal - XO; Component Kind: Standard; Datasheet PDF: http://www.abracon.com/Resonators/abm8.pdf; Library Name: CommunityVault; Octopart Url: https://octopart.com/abm8-27.000mhz-10-1-u-t-abracon-657792; Package / Case: 4 SMD Custom 3.2mm x 2.5mm; RoHS: Compliant; Supplier Name: Digikey; Supplier PN: 535-9679-1-ND; Supplier URL: http://www.digikey.ca/product-detail/en/abracon-llc/ABM8-27.000MHZ-10-1-U-T/535-9679-1-ND/1237146
